# Altium schematic sheet schematic.SchDoc(schematic)
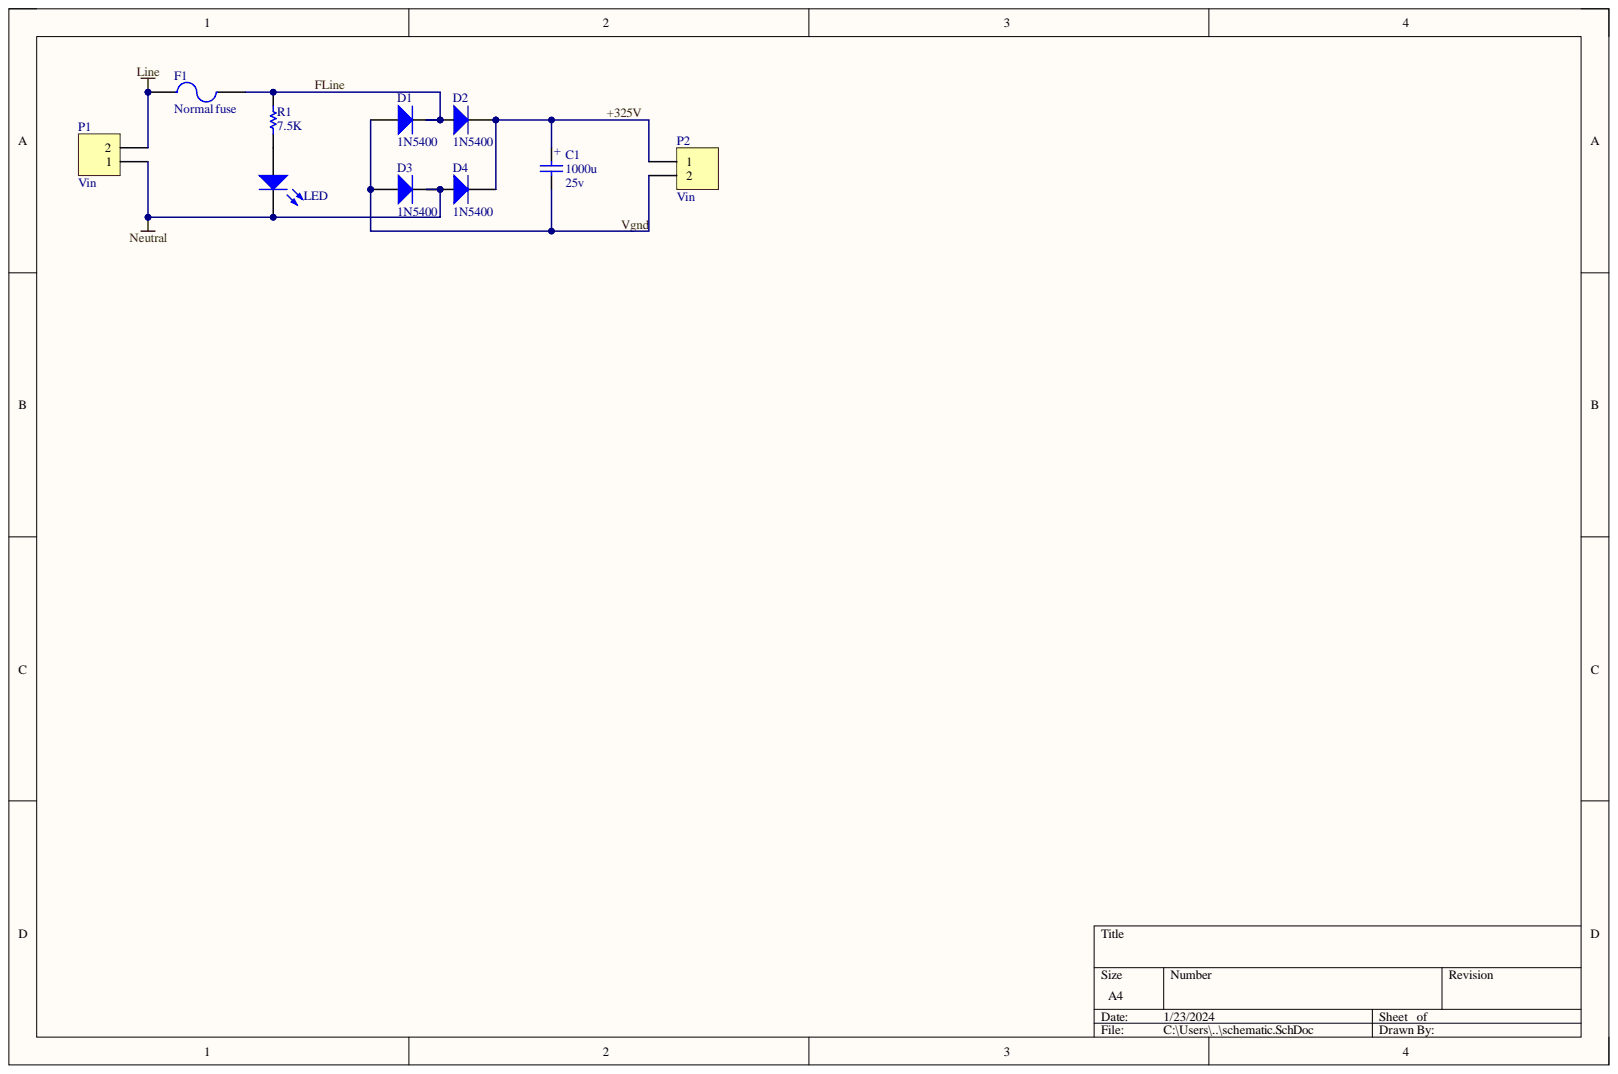
[diagram p0: schematic.SchDoc]

## Parts
C1  1000u  Volte: 25v  [MY_E5-13mm]
D1  1N5400  [My_DO201-15]
D2  1N5400  [My_DO201-15]
D3  1N5400  [My_DO201-15]
D4  1N5400  [My_DO201-15]
F1  Normal fuse  [MY_FUSE]
P1  Vin  [MY_MSTBA2]
P2  Vin  [MY_MSTBA2]
R1  7.5K  [MY_(0.5w)_0411_15]
  LED  [MY_LED5MM]

## Nets
Line: F1.1 P1.2
Net_A: R1.2 .A
Neutral: D3.K D4.A P1.1 .K
+325V: C1.+ D2.K D4.K P2.1
FLine: D1.K D2.A F1.2 R1.1
Vgnd: C1.- D1.A D3.A P2.2

## Library data of the parts
C1 = Cap Pol: Polar capacitor; ASiD Part Number: C-AS; Component Kind: Standard; Library Name: Mylib+Rev+4.IntLib; Value: 1000u
D1-D4 = 1N5400: 3 Amp Diode; ASiD Part Number: 1N5408-AS; Component Kind: Standard; Library Name: Mylib+Rev+4.IntLib
F1 = Fuse: ASiD Part Number: Fuse-AS; Component Kind: Standard; Description: Normal fuse; Library Name: Mylib+Rev+4.IntLib
P1 P2 = Header 2: Header, 2-Pin; ASiD Part Number: Header 2-AS; Component Kind: Standard; Library Name: Mylib+Rev+4.IntLib; Signal Integrity: Connector
R1 = Res: Standard resistor; ASiD Part Number: Res-AS; Component Kind: Standard; Library Name: Mylib+Rev+4.IntLib; Value: 7.5K
 = LED: Typical INFRARED GaAs LED; ASiD Part Number: LED-AS; Component Kind: Standard; Library Name: Mylib+Rev+4.IntLib
